# Altium schematic sheet power.SchDoc(power)
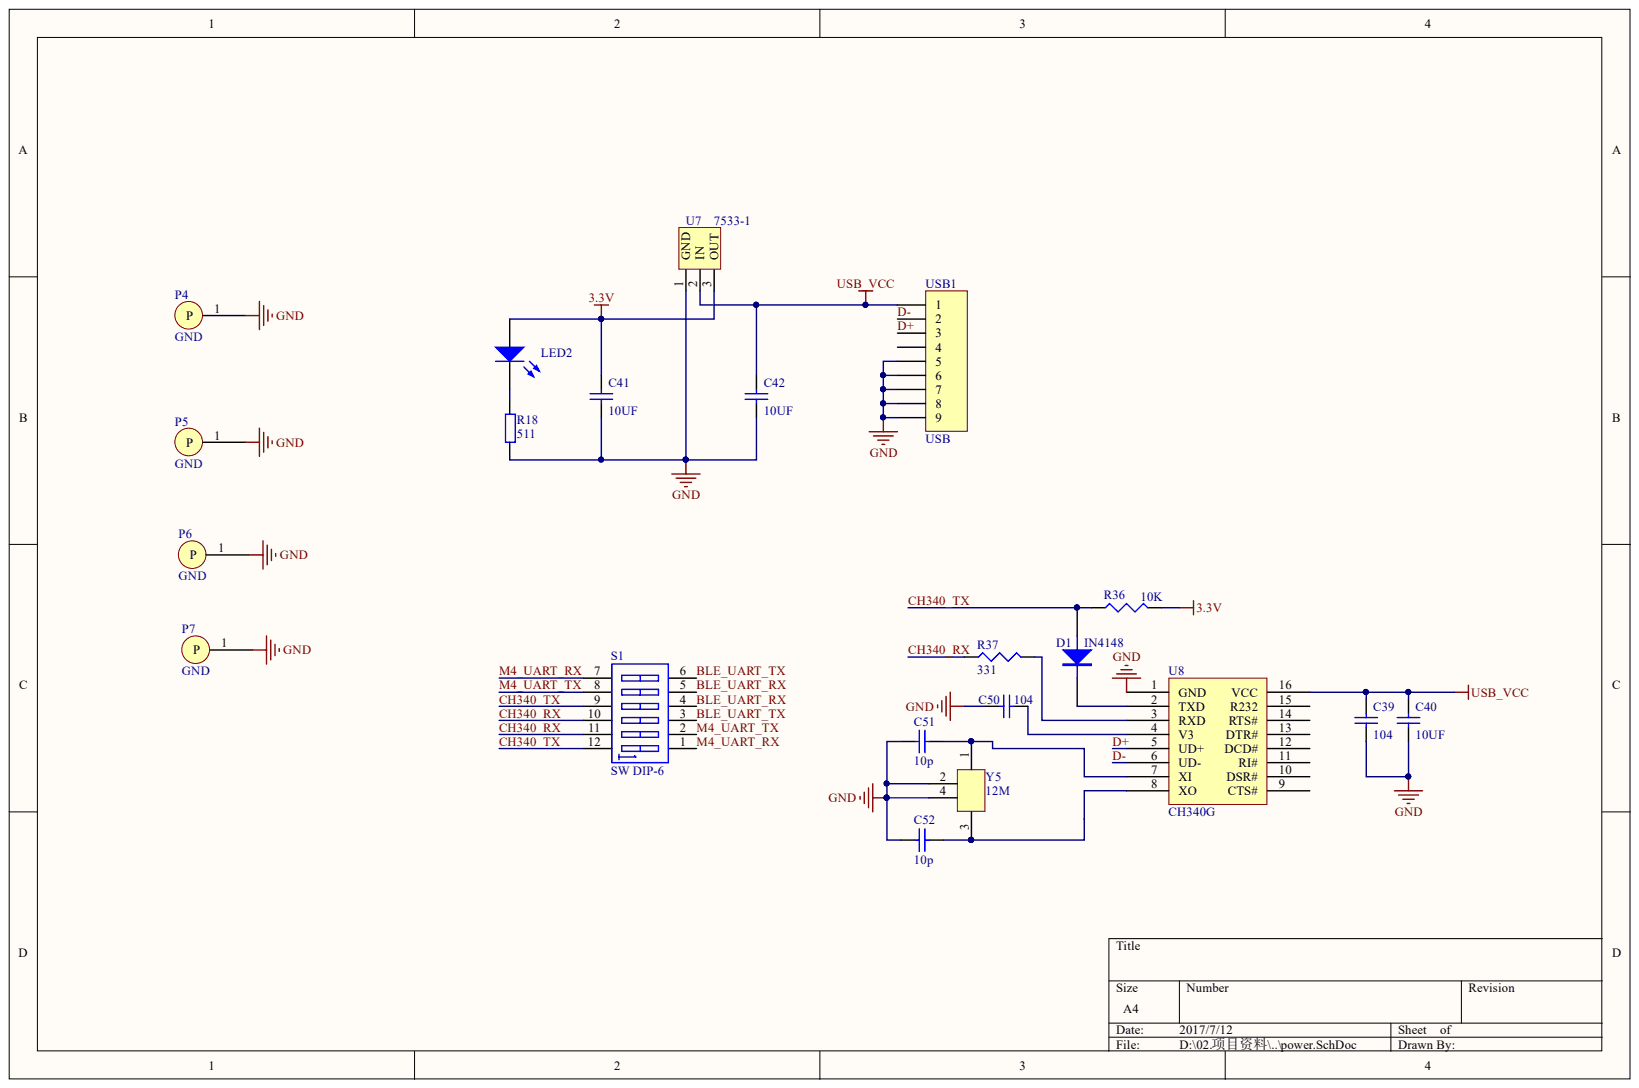
[diagram p0: power.SchDoc]

## Parts
C39  104  [0603P]
C40  10UF  [0603P]
C41  10UF  [0603P]
C42  10UF  [0603P]
C50  104  [0603P]
C51  10p  [0603P]
C52  10p  [0603P]
D1  IN4148  [DIODE_SOP]
LED2  LED1  [SMDLED]  (value not drawn)
P4  GND  [VIA]
P5  GND  [VIA]
P6  GND  [VIA]
P7  GND  [VIA]
R18  511  [0603P]
R36  10K  [0603P]
R37  331  [0603P]
S1  SW DIP-6  [SWI_6]
U7  7533-1  [SOT89]
U8  CH340G  [SOP16M]
USB1  USB  [MICRO_USB_DIP4]
Y5  12M  [XTAL_4p]

## Nets
3.3V: C41.1 LED2.1 R36.2 U7.3
GND: C39.2 C40.2 C41.2 C42.2 C50.2 C51.1 C52.1 P4.1 P5.1 P6.1 P7.1 R18.1 U7.1 U8.1(GND) USB1.5 USB1.6 USB1.7 USB1.8 USB1.9 Y5.2 Y5.4
USB_VCC: C39.1 C40.1 C42.1 U7.2 U8.16(VCC) USB1.1
BLE_UART_RX: S1.4 S1.5
BLE_UART_TX: S1.3 S1.6
CH340_RX: R37.1 S1.10 S1.11
CH340_TX: D1.1 R36.1 S1.9 S1.12
D+: U8.5(UD+) USB1.3
D-: U8.6(UD-) USB1.2
M4_UART_RX: S1.1 S1.7
M4_UART_TX: S1.2 S1.8
NetC50_1: C50.1 U8.4(V3)
NetC51_2: C51.2 U8.7(XI) Y5.1
NetC52_2: C52.2 U8.8(XO) Y5.3
NetD1_2: D1.2 U8.2(TXD)
NetLED2_2: LED2.2 R18.2
NetR37_2: R37.2 U8.3(RXD)

Not connected: U8 9(CTS#) 10(DSR#) 11(RI#) 12(DCD#) 13(DTR#) 14(RTS#) 15(R232); USB1 4

## Library data of the parts
C39 C40 C42 C50 = Cap__: Value: 100pF; Component Kind: Standard; LatestRevisionDate: 29-May-2009; LatestRevisionNote: IPC-7351 Footprint Added.; Library Name: Schlib1.SchLib; PackageDescription: Chip Capacitor; PackageReference: C0805; Published: 8-Jun-2000; Publisher: Altium Limited
C41 = Cap__: Component Kind: Standard; LatestRevisionDate: 29-May-2009; LatestRevisionNote: IPC-7351 Footprint Added.; Library Name: Schlib1.SchLib; PackageDescription: Chip Capacitor; PackageReference: C0805; Published: 8-Jun-2000; Value: 10uf; Publisher: Altium Limited
C51 C52 = Cap: Capacitor; Value: 104; Component Kind: Standard; LatestRevisionDate: 17-Jul-2002; LatestRevisionNote: Re-released for DXP Platform.; Library Name: smart_home_main_Project.SCHLIB; PackageDescription: Radial Cap, Thru-Hole; 2 Leads; 0.3 in Pin Spacing; PackageReference: RAD-0.3; Published: 8-Jun-2000; Signal Integrity: Cap; Simulation: CAP; Publisher: Altium Limited
D1 = DIODE: Component Kind: Standard; Description: Diode; Library Name: Schlib1.SchLib
LED2 = LED2: Typical RED, GREEN, YELLOW, AMBER GaAs LED; Component Kind: Standard; LatestRevisionDate: 17-Jul-2002; LatestRevisionNote: Re-released for DXP Platform.; Library Name: smart_home_main_Project.SCHLIB; PackageDocument: Feb-2002; PackageReference: 3.2X1.6X1.1; Published: 8-Jun-2000; Simulation: LED2; Publisher: Altium Limited
P4-P7 = HEADER1: Component Kind: Standard; Library Name: smart_home_main_Project.SCHLIB
R18 = R: Component Kind: Standard; Library Name: smart_home_main_Project.SCHLIB
R36 R37 = Res1: Resistor; Value: 1K; Component Kind: Standard; LatestRevisionDate: 29-May-2009; LatestRevisionNote: IPC-7351 Footprint Added.; Library Name: Schlib1.SchLib; PackageDescription: Chip Resistor; PackageReference: J1-0603; Published: 8-Jun-2000; Publisher: Altium Limited
S1 = SW DIP-6: DIP Switch, 6 Position, SPST; Component Kind: Standard; LatestRevisionDate: 17-Jul-2002; LatestRevisionNote: Re-released for DXP Platform.; Library Name: Miscellaneous Devices.IntLib; PackageDescription: DIP Switch; 12 Leads; PackageReference: DIP-12/SW; Published: 24-Mar-1999; Simulation: dpsw6; Publisher: Altium Limited
U7 = WY: Component Kind: Standard; Library Name: Schlib1.SchLib
USB1 = Header 9: Header, 9-Pin; Component Kind: Standard; LatestRevisionDate: 17-Jul-2002; LatestRevisionNote: Re-released for DXP Platform.; Library Name: Miscellaneous Connectors.IntLib; Publisher: Altium Limited
Y5 = XTAL_4: Component Kind: Standard; Library Name: Y1.SchLib
U8 = CH340G: Component Kind: Standard; Library Name: Schlib1.SchLib
